# Altium schematic sheet 02-USER_IO.SchDoc(02-USER_IO)
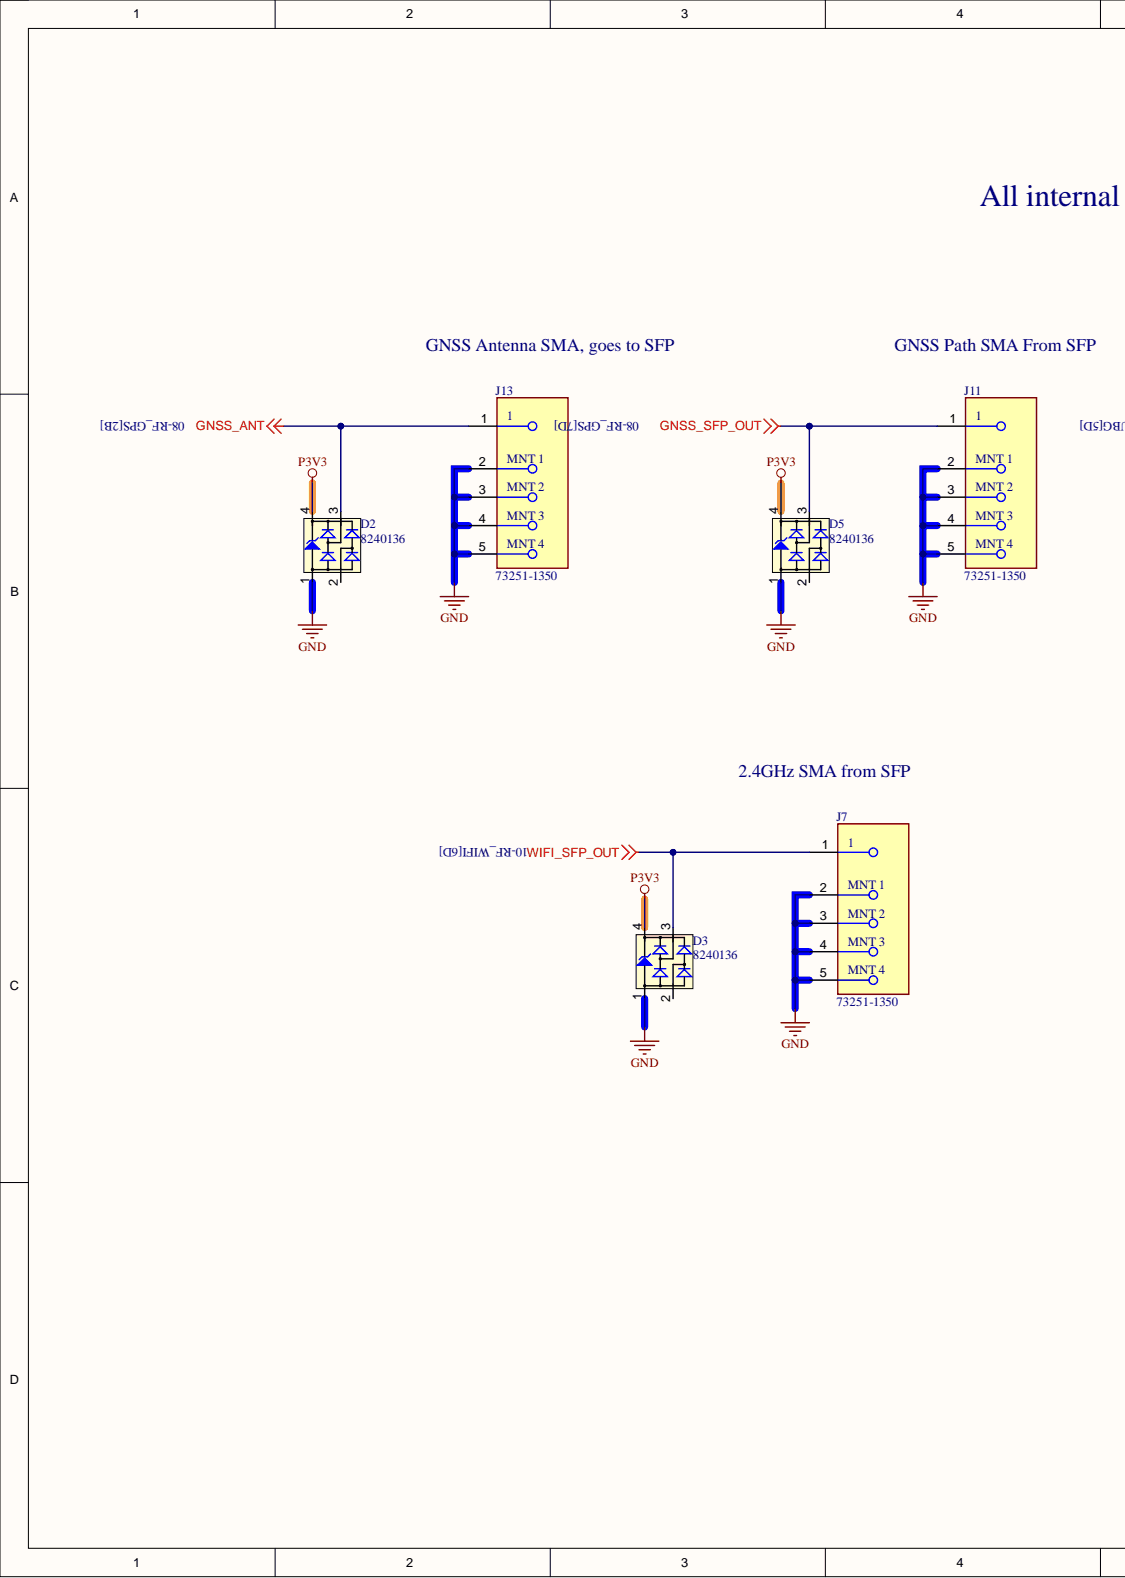
[diagram p0: 02-USER_IO.SchDoc, left]
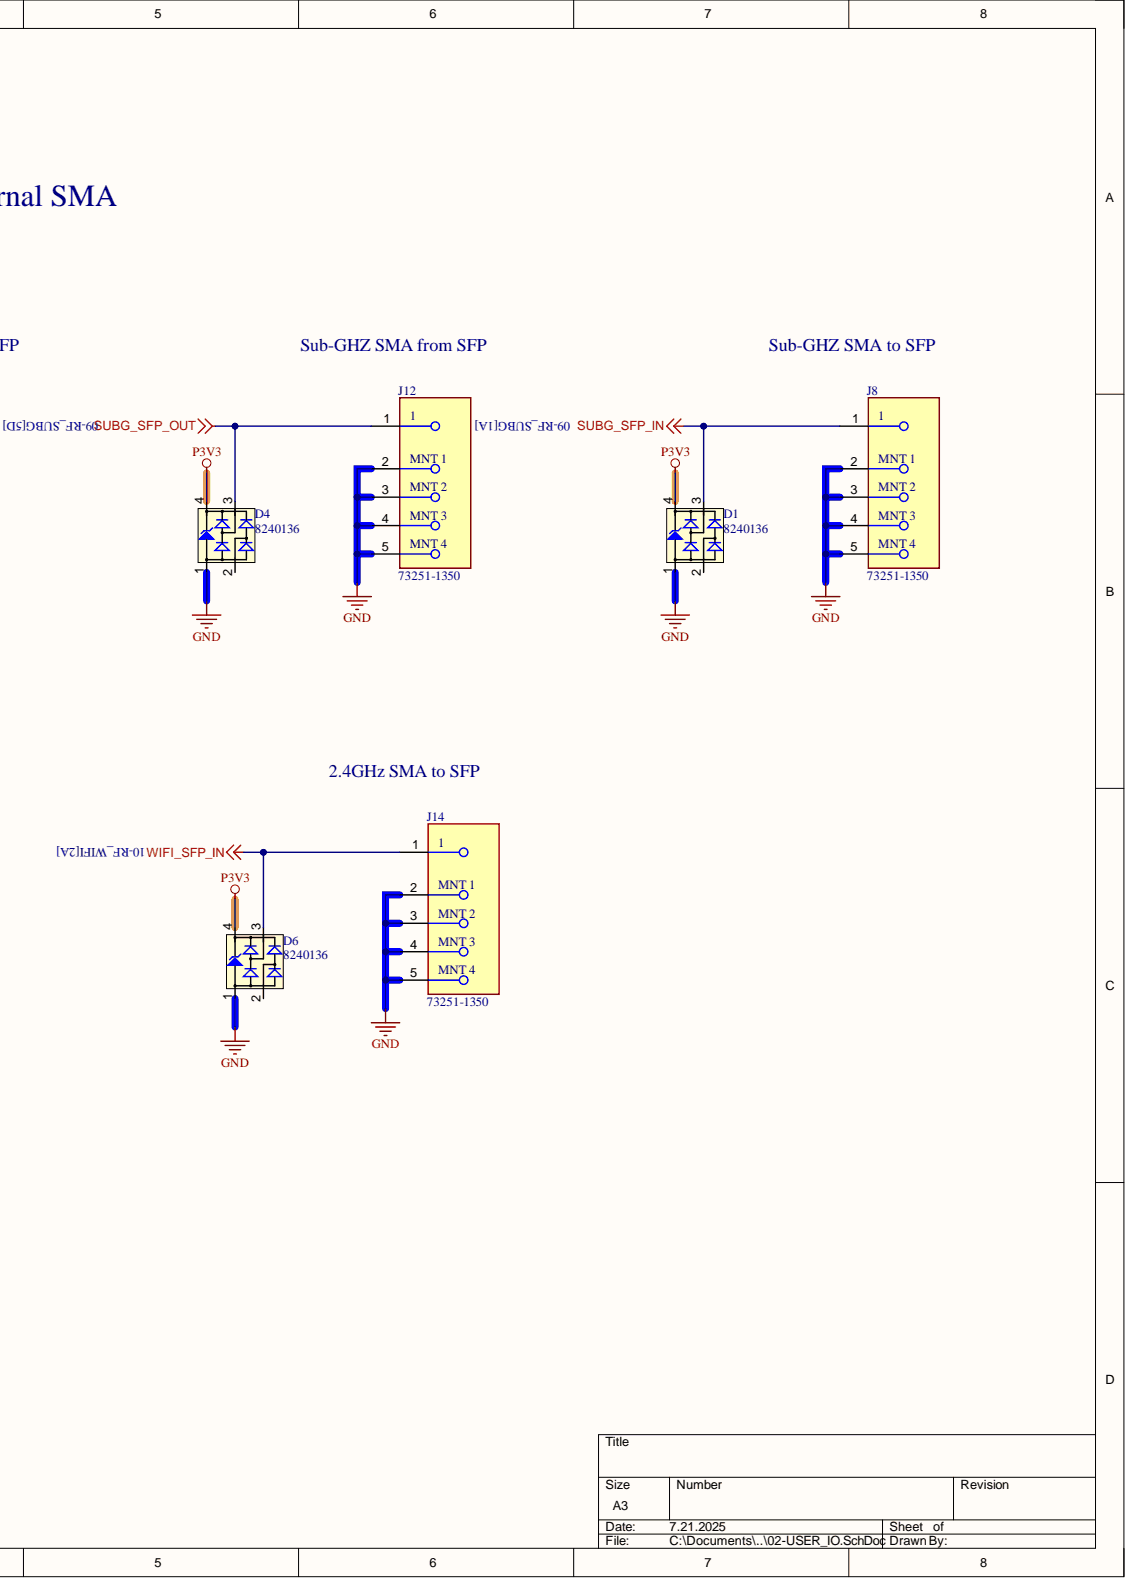
[diagram p1: 02-USER_IO.SchDoc, right]

## Parts
D1  8240136  [SOT143-4L]
D2  8240136  [SOT143-4L]
D3  8240136  [SOT143-4L]
D4  8240136  [SOT143-4L]
D5  8240136  [SOT143-4L]
D6  8240136  [SOT143-4L]
J7  73251-1350  [FP-73251-1350-MFG]
J8  73251-1350  [FP-73251-1350-MFG]
J11  73251-1350  [FP-73251-1350-MFG]
J12  73251-1350  [FP-73251-1350-MFG]
J13  73251-1350  [FP-73251-1350-MFG]
J14  73251-1350  [FP-73251-1350-MFG]

## Nets
GND: D1.1 D2.1 D3.1 D4.1 D5.1 D6.1 J7.2 J7.3 J7.4 J7.5 J8.2 J8.3 J8.4 J8.5 J11.2 J11.3 J11.4 J11.5 J12.2 J12.3 J12.4 J12.5 J13.2 J13.3 J13.4 J13.5 J14.2 J14.3 J14.4 J14.5
GNSS_ANT: D2.3 J13.1
GNSS_SFP_OUT: D5.3 J11.1
P3V3: D1.4 D2.4 D3.4 D4.4 D5.4 D6.4
SUBG_SFP_IN: D1.3 J8.1
SUBG_SFP_OUT: D4.3 J12.1
WIFI_SFP_IN: D6.3 J14.1
WIFI_SFP_OUT: D3.3 J7.1

Not connected: D1 2; D2 2; D3 2; D4 2; D5 2; D6 2

## Library data of the parts
D1-D6 = CMP-1486-00002-1: TVS Diode WE-TVS-HS, VRWM=3.3V; Manufacturer: Wuerth Elektronik; Reverse Stand Off Voltage: 3.3V; Component Kind: Standard; ComponentLink1Description: Manufacturer URL; ComponentLink1URL: http://www.we-online.com; ComponentLink2Description: Datasheet; ComponentLink2URL: http://katalog.we-online.de/kataloge/eisos/media/pdf/8240136.pdf; Library Name: Altium Content Vault; PackageReference: SOT143-4L; PartNumber: 8240136
J7 J8 J11-J14 = CMP-04764-000006-1: CONN SMA JACK STR 50 OHM SMD; Manufacturer: Molex; Conn Orientation: Straight; Frequency: 18GHz; Impedance: 50Ω; Is Female: yes; Number Of Contacts: 1; Shielding: true; Standoff Height: 0.41mm; Voltage Rating: 500V; Automotive: No; Category: Conn; Component Kind: Standard; ComponentLink2Description: Datasheet; ComponentLink2URL: https://www.molex.com/pdm_docs/sd/732511350_sd.pdf; Contact Material: Gold; Device Class L1: Connectors; Device Class L2: RF / Coaxial Connectors; Device Class L3: unset; Digikey Description: CONN SMA JACK STR 50 OHM SMD; Footprint Url: https://forum.upverter.com/uploads/default/original/3X/d/a/daeafa2ce4908d4fc4e49f0b17d7d2c986fce444.pdf; Height: 9.655mm; Lead Free: Yes; Library Name: Altium Content Vault; Manufacturer Part Number: 73251-1350; Mouser Description: RF Connectors / Coaxial Connectors SMA ST PCB-JCK STD SMT; Octopart: https://octopart.com/73251-1350-molex-5414390; Package: 73251-1350; RoHS: TRUE; Temperature: -65°C to +165°C; Temperature Range High: +165°C; Temperature Range Low: -65°C
